# Altium schematic sheet Sheet2.SchDoc(Sheet2)
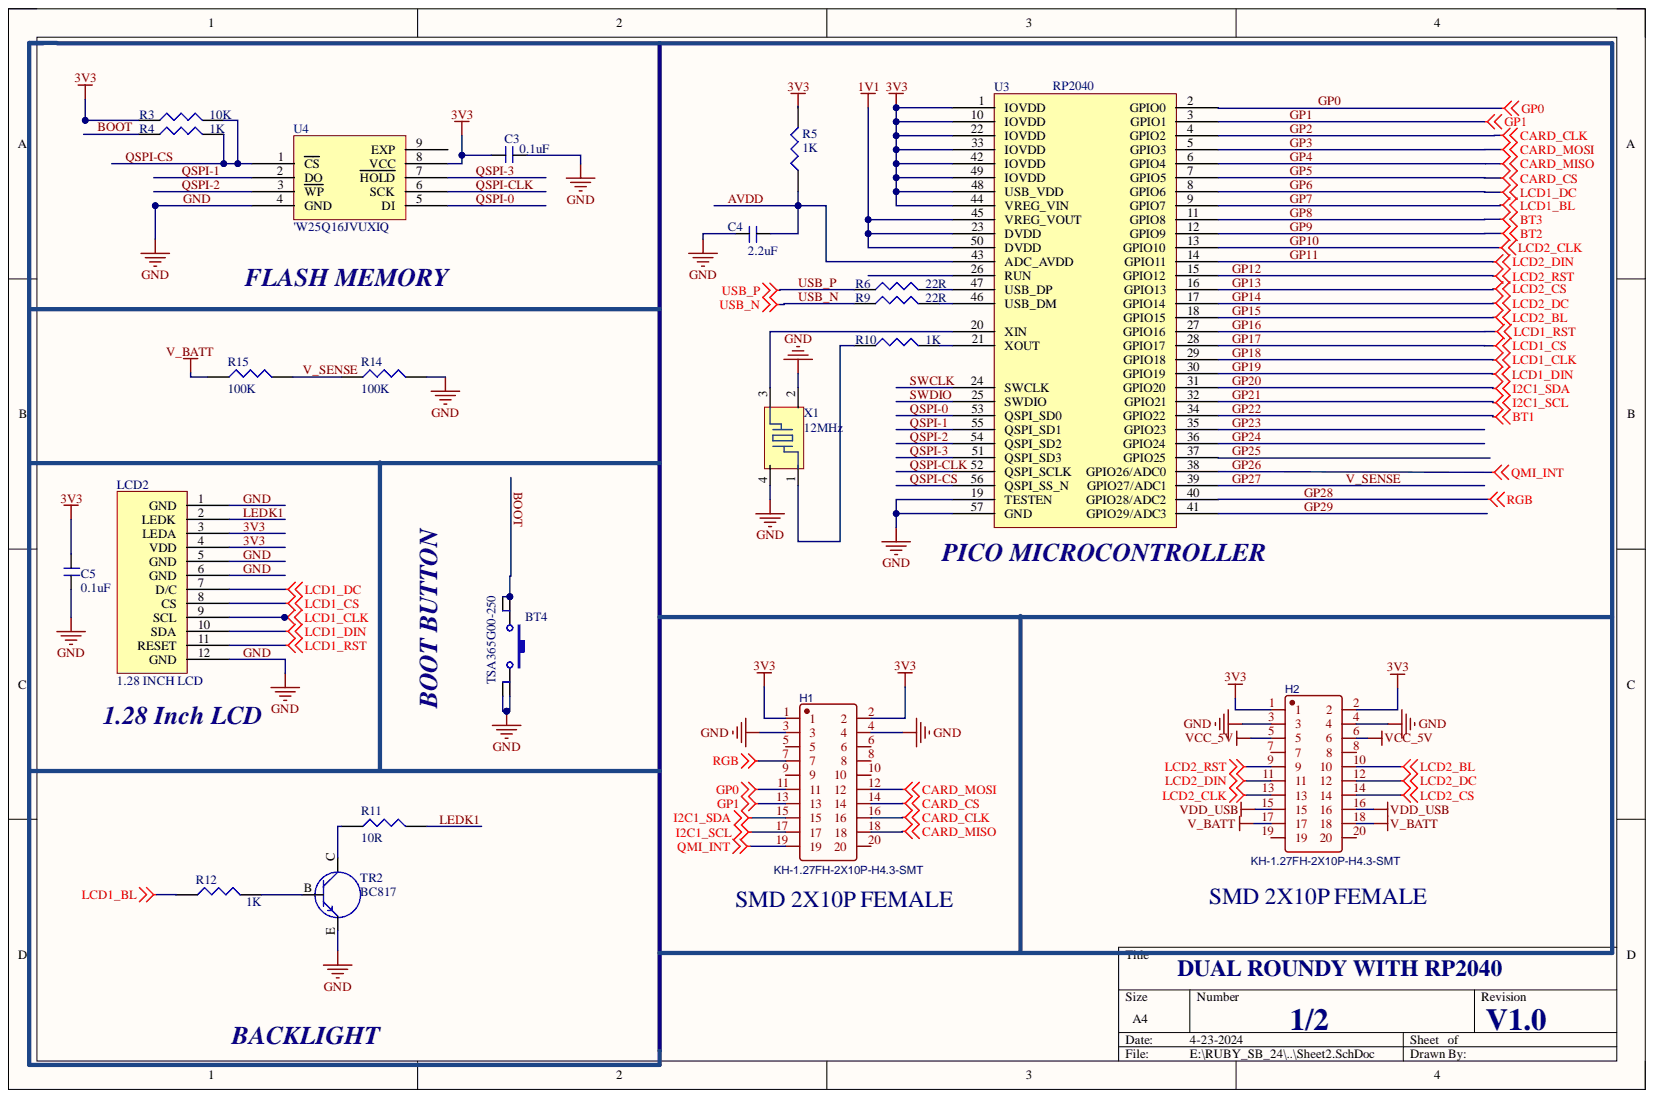
[diagram p0: Sheet2.SchDoc]

## Parts
BT4  TSA365G00-250  [TACT_]
C3  0.1uF  [C_0603]
C4  2.2uF  [C_0603]
C5  0.1uF  [C_0603]
H1  KH-1.27FH-2X10P-H4.3-SMT  [SMD 2X10P 1.27MM FEMALE]
H2  KH-1.27FH-2X10P-H4.3-SMT  [SMD 2X10P 1.27MM FEMALE]
LCD2  1.28 INCH LCD  [1.28 ROUND NON TOUCH]
R3  10K  [R_0603]
R4  1K  [R_0603]
R5  1K  [R_0603]
R6  22R  [R_0603]
R9  22R  [R_0603]
R10  1K  [R_0603]
R11  10R  [R_0603]
R12  1K  [R_0603]
R14  100K  [R_0603]
R15  100K  [R_0603]
TR2  BC817  [SOT23]
U3  RP2040  [QFN56]
U4  'W25Q16JVUXIQ  [USON-8]
X1  12MHz  [crystal]

## Nets
1V1: U3.23(DVDD) U3.45(VREG_VOUT) U3.50(DVDD)
QMI_INT: H1.19 U3.38(GPIO26/ADC0)
V_BATT: H2.17 H2.18 R15.1
VCC_5V: H2.5 H2.6
VDD_USB: H2.15 H2.16
3V3: C3.2 C5.2 H1.1 H1.2 H2.1 H2.2 LCD2.3(LEDA) LCD2.4(VDD) R3.1 R5.2 U3.1(IOVDD) U3.10(IOVDD) U3.22(IOVDD) U3.33(IOVDD) U3.42(IOVDD) U3.44(VREG_VIN) U3.48(USB_VDD) U3.49(IOVDD) U4.8(VCC)
AVDD: C4.2 R5.1 U3.43(ADC_AVDD)
BOOT: BT4.1 BT4.2 R4.1
GND: BT4.3 BT4.4 C3.1 C4.1 C5.1 H1.3 H1.4 H2.3 H2.4 LCD2.1(GND) LCD2.5(GND) LCD2.6(GND) LCD2.12(GND) R14.2 TR2.E U3.19(TESTEN) U3.57(GND) U4.4(GND) X1.2 X1.4
GP0: H1.11 U3.2(GPIO0)
GP1: H1.13 U3.3(GPIO1)
GP2: H1.16 U3.4(GPIO2)
GP3: H1.12 U3.5(GPIO3)
GP4: H1.18 U3.6(GPIO4)
GP5: H1.14 U3.7(GPIO5)
GP6: LCD2.7(D/C) U3.8(GPIO6)
GP7: R12.1 U3.9(GPIO7)
GP8: U3.11(GPIO8)
GP9: U3.12(GPIO9)
GP10: H2.13 U3.13(GPIO10)
GP11: H2.11 U3.14(GPIO11)
GP12: H2.9 U3.15(GPIO12)
GP13: H2.14 U3.16(GPIO13)
GP14: H2.12 U3.17(GPIO14)
GP15: H2.10 U3.18(GPIO15)
GP16: LCD2.11(RESET) U3.27(GPIO16)
GP17: LCD2.8(CS) U3.28(GPIO17)
GP18: LCD2.9(SCL) U3.29(GPIO18)
GP19: LCD2.10(SDA) U3.30(GPIO19)
GP20: H1.15 U3.31(GPIO20)
GP21: H1.17 U3.32(GPIO21)
GP22: U3.34(GPIO22)
GP23: U3.35(GPIO23)
GP24: U3.36(GPIO24)
GP25: U3.37(GPIO25)
GP27: R14.1 R15.2 U3.39(GPIO27/ADC1)
GP28: H1.7 U3.40(GPIO28/ADC2)
GP29: U3.41(GPIO29/ADC3)
LEDK1: LCD2.2(LEDK) R11.2
QSPI-0: U3.53(QSPI_SD0) U4.5(DI)
QSPI-1: U3.55(QSPI_SD1) U4.2(DO)
QSPI-2: U3.54(QSPI_SD2) U4.3(WP)
QSPI-3: U3.51(QSPI_SD3) U4.7(HOLD)
QSPI-CLK: U3.52(QSPI_SCLK) U4.6(SCK)
QSPI-CS: R3.2 R4.2 U3.56(QSPI_SS_N) U4.1(CS)
SWCLK: U3.24(SWCLK)
SWDIO: U3.25(SWDIO)
USB_N: R9.1
USB_P: R6.1
NetR6_2: R6.2 U3.47(USB_DP)
NetR9_2: R9.2 U3.46(USB_DM)
NetR10_1: R10.1 X1.1
NetR10_2: R10.2 U3.21(XOUT)
NetR11_1: R11.1 TR2.C
NetR12_2: R12.2 TR2.B
NetU3_20: U3.20(XIN) X1.3
GP26:

Not connected: H1 5 6 8 9 10 20; H2 7 8 19 20; U3 26(RUN); U4 9(EXP)

## Library data of the parts
BT4 = TACTILE_SWITCH: Component Kind: Standard; Library Name: dxp_pasl_lib.IntLib
C3-C5 = CAP_NONPOLAR: Component Kind: Standard; Library Name: dxp_pasl_lib.IntLib
H1 H2 = KH-1.27FH-2X10P-H4.3-SMT: BOM_Manufacturer: kinghelm(金航标); Contributor: LCSC; BOM_JLCPCB Part Class: Extended Part; BOM_Manufacturer Part: KH-1.27FH-2X10P-H4.3-SMT; BOM_Supplier: LCSC; BOM_Supplier Part: C2938399; Component Kind: Standard; spicePre: U; spiceSymbolName: KH-1.27FH-2X10P-H4.3-SMT
LCD2 = LCD ROUND: 1.28 INCH ROUND LCD GC9A01; Component Kind: Standard; Library Name: dxp_pasl_lib.IntLib
R3-R6 R9-R12 R14 R15 = RES: Component Kind: Standard; Library Name: dxp_pasl_lib.IntLib
TR2 = TRANSISTER_NPN: Component Kind: Standard; Library Name: dxp_pasl_lib.IntLib
U3 = RP2040: A MICROCONTROLLER BY RPI; Component Kind: Standard; Library Name: dxp_pasl_lib.IntLib
U4 = W25Q16FWUXIE: FLASH - NOR USON-8_2x3mm ROHS #C401672; Component Kind: Standard; Library Name: dxp_pasl_lib.IntLib
X1 = CRYSTAL_OSCILATOR: 12MHz ±10ppm 12pF 80Ω SMD-3225_4P Crystals RoHS #C70565; Component Kind: Standard; Library Name: dxp_pasl_lib.IntLib
